# Altium schematic sheet QuadDeckV2.SchDoc
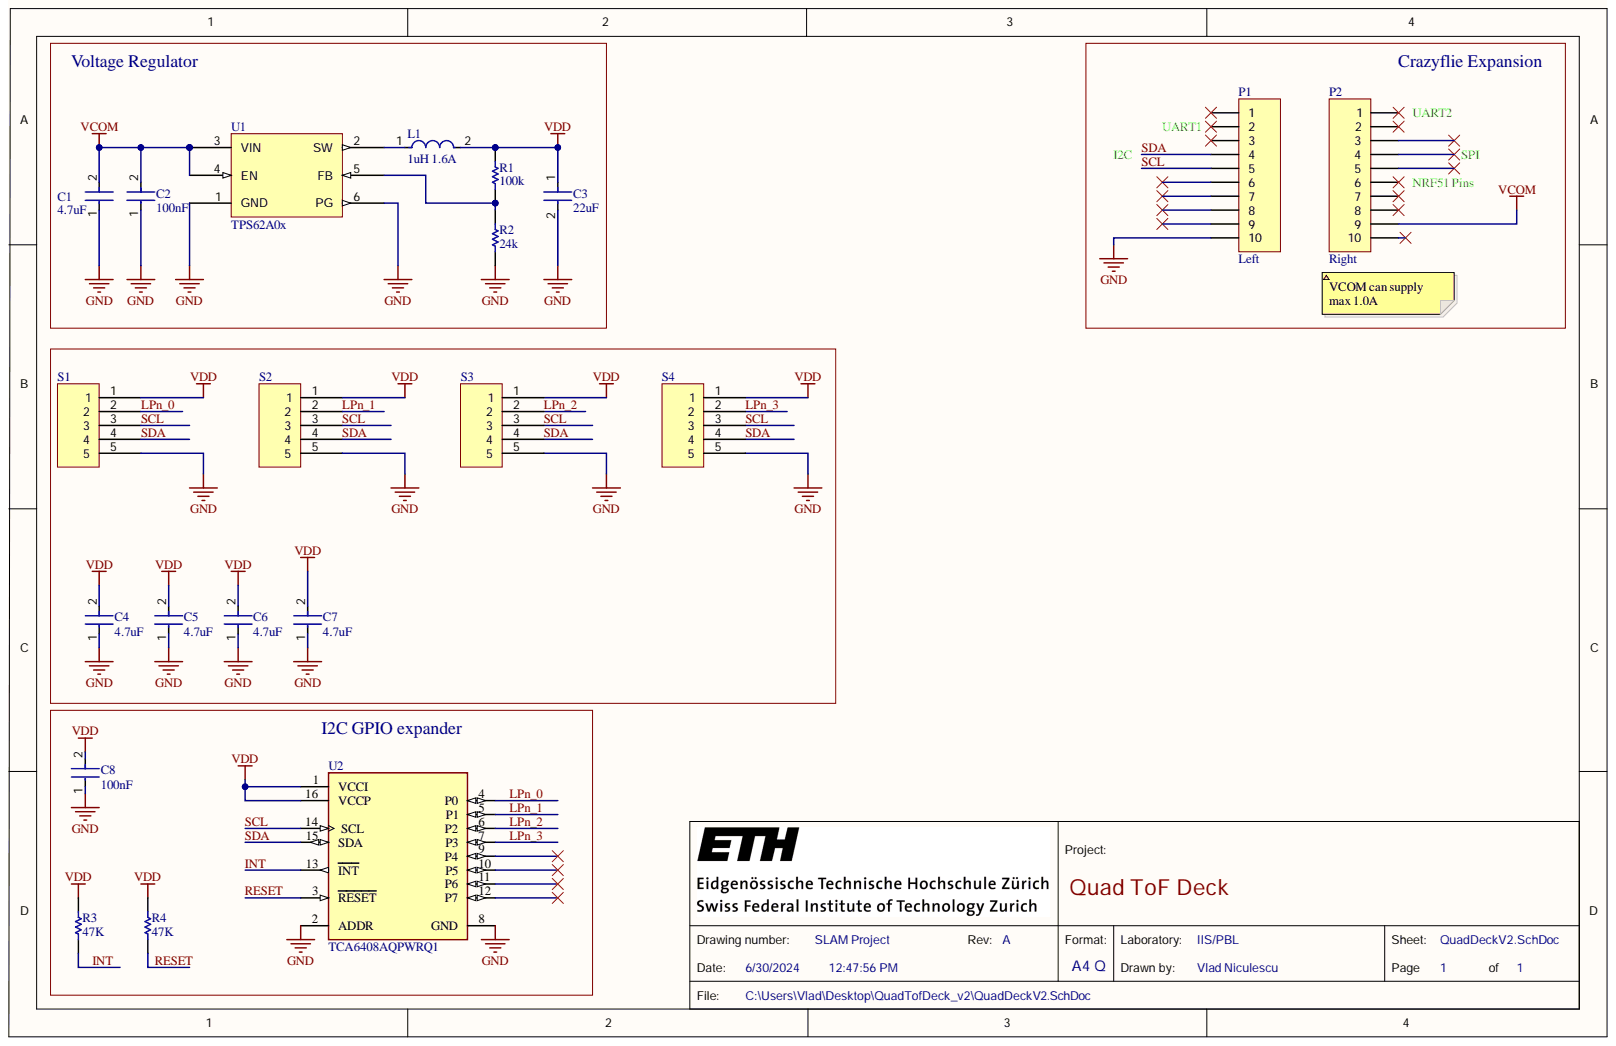
[diagram p0: QuadDeckV2.SchDoc]

## Parts
C1  4.7uF  [FP-GRM188-0_1-MFG]
C2  100nF  [FP-GRM15-MFG]
C3  22uF  [FP-GRM21B-0_15-MFG]
C4  4.7uF  [FP-GRM188-0_1-MFG]
C5  4.7uF  [FP-GRM188-0_1-MFG]
C6  4.7uF  [FP-GRM188-0_1-MFG]
C7  4.7uF  [FP-GRM188-0_1-MFG]
C8  100nF  [FP-GRM15-MFG]
L1  1uH 1.6A  Current Rating: 1.6A  Inductance: 1uH  [FP-LQM21PN_EH-w1_5-IPC_A]
P1  Left  [PCBComponent_1]
P2  Right  [PCBComponent_1]
R1  100k  [RESC1608X55X30ML20T20]
R2  24k  [RESC0603(1608)_N]
R3  47K  [RESC1608X55X30NL15T15]
R4  47K  [RESC1608X55X30NL15T15]
S1  Component_1  [tof-connector]  (value not drawn)
S2  Component_1  [tof-connector]  (value not drawn)
S3  Component_1  [tof-connector]  (value not drawn)
S4  Component_1  [tof-connector]  (value not drawn)
U1  TPS62A0x  [SOT50P245X110-6N]
U2  TCA6408AQPWRQ1  [FP-PW0016A-IPC_B]

## Nets
GND: C1.1 C2.1 C3.2 C4.1 C5.1 C6.1 C7.1 C8.1 P1.10 R2.1 S1.5 S2.5 S3.5 S4.5 U1.1(GND) U1.6(PG) U2.2(ADDR) U2.8(GND)
VCOM: C1.2 C2.2 P2.9 U1.3(VIN) U1.4(EN)
VDD: C3.1 C4.2 C5.2 C6.2 C7.2 C8.2 L1.2 R1.2 R3.2 R4.2 S1.1 S2.1 S3.1 S4.1 U2.1(VCCI) U2.16(VCCP)
~{INT}: R3.1 U2.13(INT)
~{RESET}: R4.1 U2.3(RESET)
LPn_0: S1.2 U2.4(P0)
LPn_1: S2.2 U2.5(P1)
LPn_2: S3.2 U2.6(P2)
LPn_3: S4.2 U2.7(P3)
SCL: P1.5 S1.3 S2.3 S3.3 S4.3 U2.14(SCL)
SDA: P1.4 S1.4 S2.4 S3.4 S4.4 U2.15(SDA)
NetL1_1: L1.1 U1.2(SW)
NetR1_1: R1.1 R2.2 U1.5(FB)

Not connected: P1 1 2 3 6 7 8 9; P2 1 2 3 4 5 6 7 8 10; U2 9(P4) 10(P5) 11(P6) 12(P7)

## Library data of the parts
C1 C4-C7 = CMP-2006-02799-3: CAP CER 4.7UF 6.3V X5R 0603; Material: Ceramic; Tolerance: 10%; Capacitance: 4.7uF; Case Code (Imperial): 0603; Case Code (Metric): 1608; Case/Package: 0603; Component Kind: Standard; Depth: 0.8mm; Dielectric: X5R; Height: 0.8mm; Lead Free: Lead Free; Length: 1.6mm; Library Name: Altium Content Vault; Max Operating Temperature: 85°C; Min Operating Temperature: -55°C; Mount: Surface Mount; Package Quantity: 1; Packaging: Tape and Reel; Radiation Hardening: No; REACH SVHC: No SVHC; RoHS Compliant: Yes; Termination: SMD/SMT; Thickness: 0.035inch; Voltage: 6.3V; Voltage Rating: 6.3V; Voltage Rating (DC): 6.3V; Width: 0.8mm
C2 C8 = CMP-2100-03641-2: CAP CER 0.1UF 16V X7R 0402; Manufacturer: Murata; Manufacturer Part Number: GRM155R71C104KA88D; Capacitance: 0.10μF; Material: Ceramic; Standoff Height: 0mm; Tolerance: 10%; Value: 0.10μF; Voltage Rating: 16Vdc; Category: Cap; Component Kind: Standard; ComponentLink2Description: Datasheet; ComponentLink2URL: https://search.murata.co.jp/Ceramy/image/img/A01X/G101/ENG/GRM155R71H471KA01-01A.pdf; Device Class L1: Passive Components; Device Class L2: Capacitors; Device Class L3: Ceramic Capacitors; Digikey Description: CAP CER 0.1UF 16V X7R 0402; Height: 0.55mm; Ipc Land Pattern Name: CAPC10050X219; Lead Free: yes; Library Name: Altium Content Vault; Mouser Description: 0402 0.1 uF 16V ±10 % Tolerance X7R SMT Multilayer Ceramic Capacitor; Octopart: https://octopart.com/grm155r71c104ka88d-murata-196880; Package: GRM15; RoHS: TRUE; Temperature: -55°C to +125°C; Temperature Characteristic: X7R; Temperature Range High: +125°C; Temperature Range Low: -55°C
C3 = CMP-2007-03385-2: Chip Multilayer Ceramic Capacitors for General Purpose, 0805, 22uF, X5R, 15%, 20%, 6.3V; Capacitance: 22uF; Case Code (Imperial): 0805; Case Code (Metric): 2012; Case/Package: 0805; Component Kind: Standard; Depth: 1.25mm; Dielectric: X5R; Height: 1.25mm; Lead Free: Lead Free; Length: 2mm; Library Name: Altium Content Vault; Material: Ceramic; Max Operating Temperature: 85°C; Min Operating Temperature: -55°C; Mount: Surface Mount; Package Quantity: 1; Packaging: Tape and Reel; Radiation Hardening: No; REACH SVHC: No SVHC; Termination: SMD/SMT; Thickness: 0.053inch; Tolerance: 20%; Voltage: 6.3V; Voltage Rating: 6.3V; Voltage Rating (DC): 6.3V; Width: 0.049inch
L1 = CMP-06042-012339-2: FIXED IND 1UH 1.6A 107 MOHM SMD; Core Material: Ferrite; DC Resistance (DCR): 107mR; Saturation Current: 1A; Self Resonant Frequency: 50MHz; Shielding: Shielded; Test Frequency: 1MHz; Tolerance: 20%; Case Code (Imperial): 0805; Case Code (Metric): 2012; Case/Package: 0805; Component Kind: Standard; Library Name: Altium Content Vault; Max Operating Temperature: 85°C; Min Operating Temperature: -40°C; Mount: Surface Mount; Packaging: Tape and Reel; RoHS Compliant: Yes
P1 P2 = MHDR1X10: Header, 10-Pin; Component Kind: Standard; LatestRevisionDate: 17-Jul-2002; LatestRevisionNote: Re-released for DXP Platform.; Library Name: Miscellaneous Connectors.IntLib; Publisher: Altium Limited
R1 = CMP-2000-00875-1: Manufacturer: Panasonic; Part Number: ERA3AEB104V; Component Kind: Standard; Library Name: Altium Content Vault
R2 = CMP-1012-00107-1: 24K 0.1W 5% 0603 (1608 Metric)  SMD; Case-EIA: 0603; Case-Metric: 1608; Value: 24000.00 Ohm; Component Kind: Standard; Library Name: Altium Content Vault; Power: 0.1 W; Technology: SMT; Tolerance: 5 %
R3 R4 = CMP-2000-00523-2: Chip Resistor, 47 KOhm, +/- 1%, 0.1 W, -55 to 155 degC, 0603 (1608 Metric), RoHS, Tape and Reel; Case\Package: 0603; Forward Current: 20 mA; Forward Voltage: 2.1 V; Illumination Colour: Red; Lens Style: Clear; Luminous Intensity: 63 mcd; Nominal Wavelength: 639 nm; Power Rating: 90 mW; Viewing Angle: 160 degrees; Case Code (Imperial): 0603; Case Code (Metric): 1608; Colour: Red; Component Kind: Standard; ComponentLink1Description: Manufacturer URL; ComponentLink1URL: http://www.panasonic.com/; ComponentLink2Description: Datasheet; ComponentLink2URL: http://industrial.panasonic.com/cdbs/www-data/pdf/RDA0000/AOA0000C86.pdf; DatasheetVersion: Apr-15; Height: 0.6 mm; Length: 1.6 mm; Library Name: Altium Content Vault; Max Operating Temperature: 100 degC; Min Operating Temperature: -40 degC; Mount: Surface Mount; Nominal Vf: 2.1 V; Package Quantity: 3000; PackageDescription: 2-Pin Surface Mount Device, Body 1.6 x 0.85 mm; PackageReference: 0603; Packaging: Tape and Reel; Pins: 2; Radiation Hardening: No; REACH SVHC: No SVHC; RoHSCompliant: true; Width: 0.8 mm
U1 = TPS62A01: Component Kind: Standard; Library Name: TPS62A01.SchLib
U2 = CMP-04886-000007-1: IC I/O EXPANDER I2C 8B 16TSSOP; Number of I/Os: 8; Case/Package: TSSOP; Component Kind: Standard; Lead Free: Lead Free; Library Name: Altium Content Vault; Max Operating Temperature: 125°C; Min Operating Temperature: -40°C; RoHS Compliant: Yes
S1-S4 = Component_1: Component Kind: Standard; Library Name: tof-connector-5.SchLib
